# Altium schematic sheet pai.SchDoc(pai)
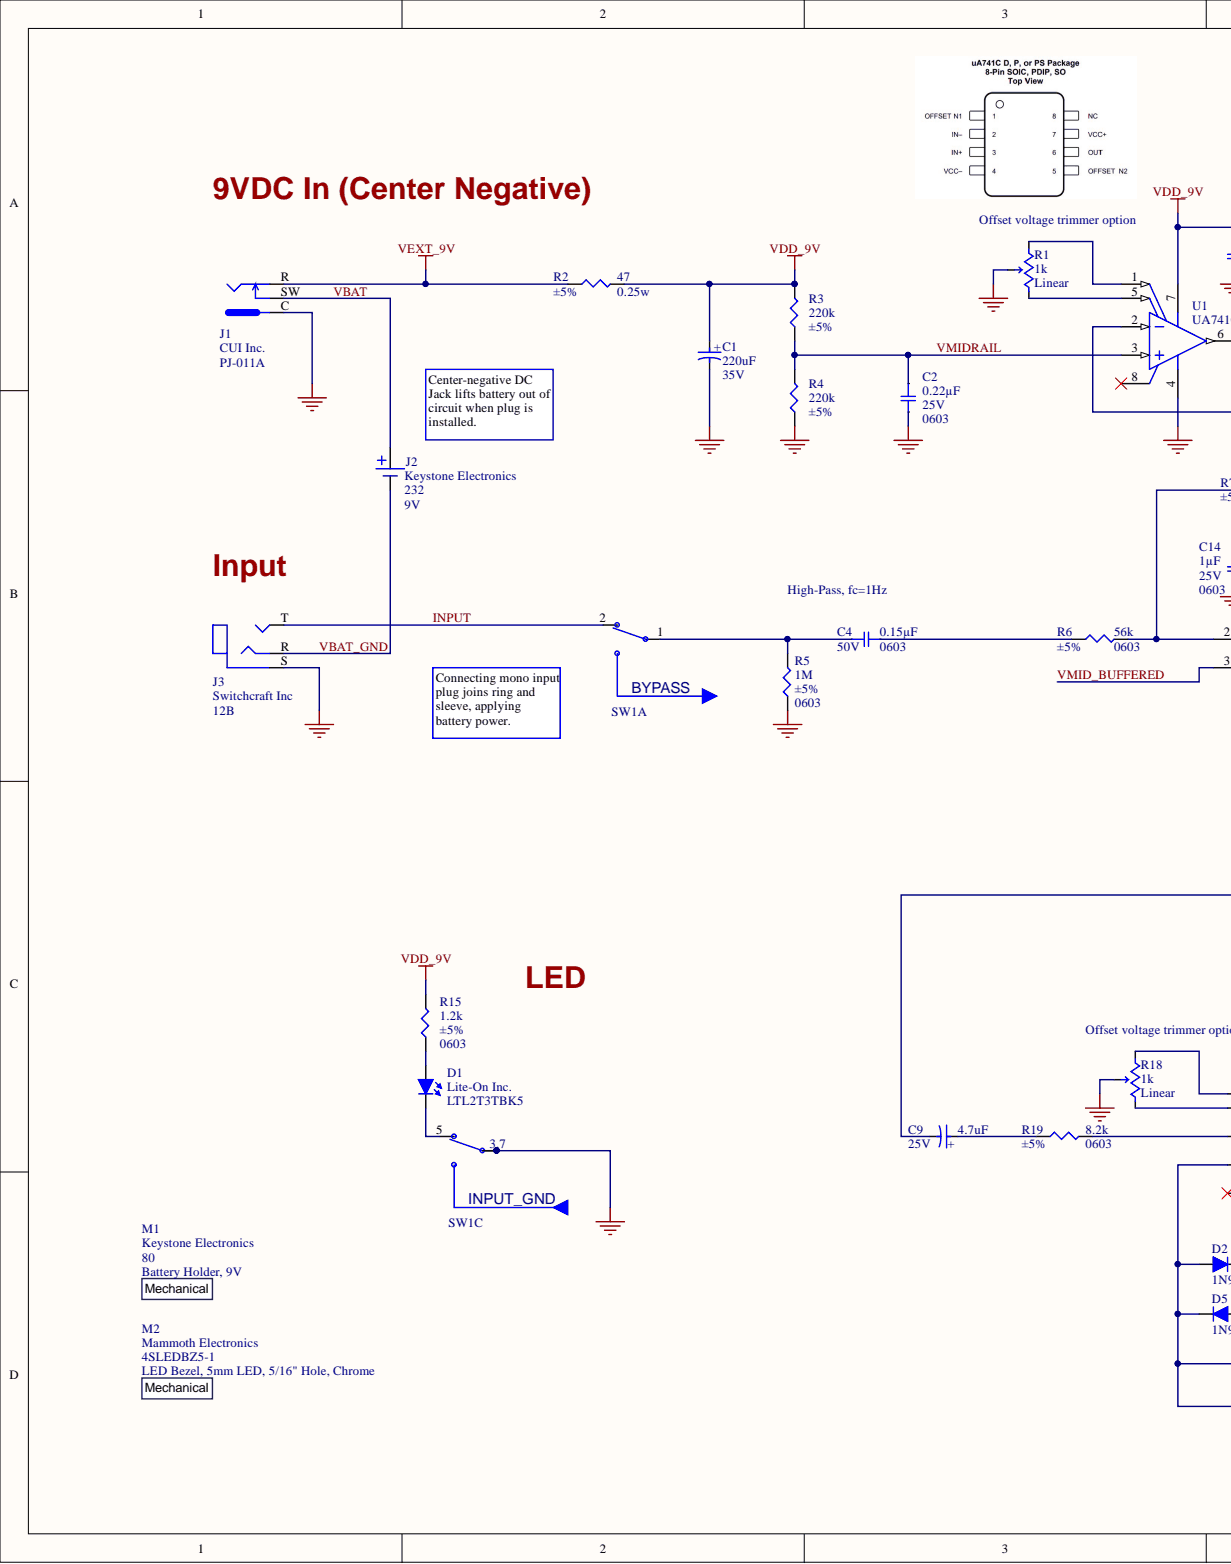
[diagram p0: pai.SchDoc, left]
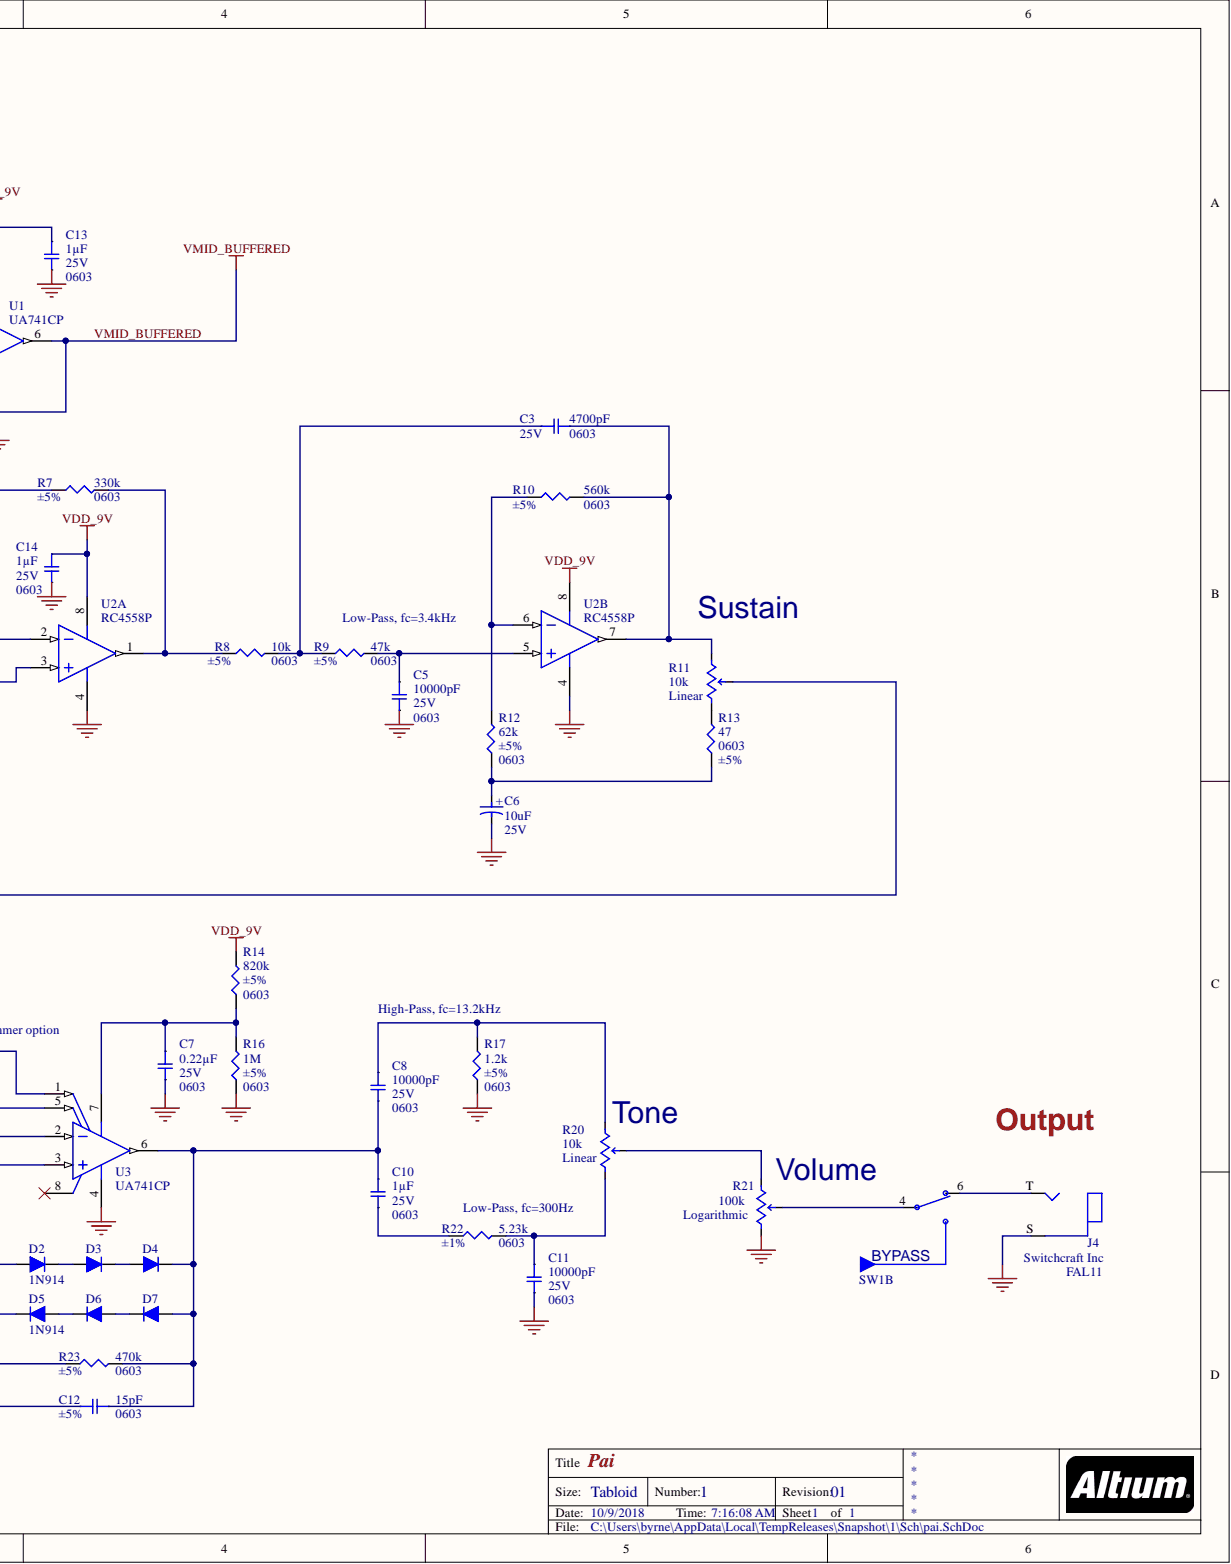
[diagram p1: pai.SchDoc, right]

## Parts
C1  value 220uF  Voltage-Rated: 35V  [CAP_TH_10X14_L5]  (hidden comment: CAP-POL)
C2  0.22µF  Voltage: 25V  Package / Case: 0603  [SMD-0603C]
C3  4700pF  Voltage: 25V  Package / Case: 0603  [SMD-0603C]
C4  0.15µF  Voltage: 50V  Package / Case: 0603  [SMD-0603C]
C5  10000pF  Voltage: 25V  Package / Case: 0603  [SMD-0603C]
C6  value 10uF  Voltage-Rated: 25V  [CAP_TH_5X12_L5]  (hidden comment: CAP-POL)
C7  0.22µF  Voltage: 25V  Package / Case: 0603  [SMD-0603C]
C8  10000pF  Voltage: 25V  Package / Case: 0603  [SMD-0603C]
C9  value 4.7uF  Voltage-Rated: 25V  [CAP_TH_5X12_L2]  (hidden comment: CAP-POL)
C10  1µF  Voltage: 25V  Package / Case: 0603  [SMD-0603C]
C11  10000pF  Voltage: 25V  Package / Case: 0603  [SMD-0603C]
C12  15pF  Tolerance: ±5%  Package / Case: 0603  [SMD-0603C]
C13  1µF  Voltage: 25V  Package / Case: 0603  [SMD-0603C]
C14  1µF  Voltage: 25V  Package / Case: 0603  [SMD-0603C]
D1  Manufacturer 1 PN: LTL2T3TBK5  [LED_TH_5MM_BLUE]  (hidden comment: LED-Blue)
D2  Manufacturer 1 PN: 1N914  [DO35-2_1N914]  (hidden comment: DIO-GEN)
D3  DIO-GEN  [DO35-2_1N914]  (value not drawn)
D4  DIO-GEN  [DO35-2_1N914]  (value not drawn)
D5  Manufacturer 1 PN: 1N914  [DO35-2_1N914]  (hidden comment: DIO-GEN)
D6  DIO-GEN  [DO35-2_1N914]  (value not drawn)
D7  DIO-GEN  [DO35-2_1N914]  (value not drawn)
J1  Manufacturer 1: CUI Inc.  [PWR_JACK_SW_HDR]  (hidden comment: PWR_JACK_SW)
J2  Battery Cell Size: 9V  [PWR_JACK_HDR]  (hidden comment: 9V_BATT)
J3  Manufacturer 1 PN: 12B  Manufacturer 1: Switchcraft Inc  [STEREO_JACK_HDR]  (hidden comment: STEREO_JACK)
J4  Manufacturer 1 PN: FAL11  Manufacturer 1: Switchcraft Inc  [MONO_JACK_HDR]  (hidden comment: MONO_JACK)
M1  Manufacturer 1: Keystone Electronics  Desc: Battery Holder, 9V  (hidden comment: MECH)  (mechanical)
M2  Supplier 1 PN: 4SLEDBZ5-1  Supplier 1: Mammoth Electronics  (hidden comment: MECH)  (mechanical)
R1  value 1k  Taper: Linear  [POT_TT35W]  (hidden comment: POT)
R2  47  Tolerance: ±5%  Power (Watts): 0.25w  [SMD-0603-RES]
R3  220k  Tolerance: ±5%  [SMD-0603-RES]
R4  220k  Tolerance: ±5%  [SMD-0603-RES]
R5  1M  Tolerance: ±5%  Supplier Device Package: 0603  [SMD-0603-RES]
R6  56k  Tolerance: ±5%  Supplier Device Package: 0603  [SMD-0603-RES]
R7  330k  Tolerance: ±5%  Supplier Device Package: 0603  [SMD-0603-RES]
R8  10k  Tolerance: ±5%  Supplier Device Package: 0603  [SMD-0603-RES]
R9  47k  Tolerance: ±5%  Supplier Device Package: 0603  [SMD-0603-RES]
R10  560k  Tolerance: ±5%  Supplier Device Package: 0603  [SMD-0603-RES]
R11  value 10k  Taper: Linear  [POT_16MM_TH_RA]  (hidden comment: POT)
R12  62k  Tolerance: ±5%  Supplier Device Package: 0603  [SMD-0603-RES]
R13  47  Tolerance: ±5%  Supplier Device Package: 0603  [SMD-0603-RES]
R14  820k  Tolerance: ±5%  Supplier Device Package: 0603  [SMD-0603-RES]
R15  1.2k  Tolerance: ±5%  Supplier Device Package: 0603  [SMD-0603-RES]
R16  1M  Tolerance: ±5%  Supplier Device Package: 0603  [SMD-0603-RES]
R17  1.2k  Tolerance: ±5%  Supplier Device Package: 0603  [SMD-0603-RES]
R18  value 1k  Taper: Linear  [POT_TT35W]  (hidden comment: POT)
R19  8.2k  Tolerance: ±5%  Supplier Device Package: 0603  [SMD-0603-RES]
R20  value 10k  Taper: Linear  [POT_16MM_TH_RA]  (hidden comment: POT)
R21  value 100k  Taper: Logarithmic  [POT_16MM_TH_RA]  (hidden comment: POT)
R22  5.23k  Tolerance: ±1%  Supplier Device Package: 0603  [SMD-0603-RES]
R23  470k  Tolerance: ±5%  Supplier Device Package: 0603  [SMD-0603-RES]
SW1  3PDT_SW_SOLDERBOARD  (value not drawn)  (parts A,B,C)
U1  UA741CP  [TI-P8]
U2  RC4558P  [TI-P8]  (parts A,B)
U3  UA741CP  [TI-P8]

## Nets
GND: C1.2 C2.2 C5.2 C6.2 C7.2 C11.2 C13.2 C14.2 J1.C J3.S J4.S R1.2 R4.1 R5.1 R16.1 R17.1 R18.2 R21.3 SW1.3 SW1.7 U1.4 U2.4 U3.4
VDD_9V: C1.1 C13.1 C14.1 R2.2 R3.2 R14.2 R15.2 U1.7 U2.8
VEXT_9V: J1.R R2.1
INPUT: J3.T SW1.2
VBAT: J1.SW J2.1
VBAT_GND: J2.2 J3.R
VMID_BUFFERED: U1.2 U1.6 U2.3
VMIDRAIL: C2.1 R3.1 R4.2 U1.3
NetC3_1: C3.1 R8.2 R9.1
NetC3_2: C3.2 R10.2 R11.1 U2.7
NetC4_1: C4.1 R5.2 SW1.1
NetC4_2: C4.2 R6.1
NetC5_1: C5.1 R9.2 U2.5
NetC6_1: C6.1 R12.1 R13.1
NetC7_1: C7.1 R14.1 R16.2 U3.7
NetC8_1: C8.1 R17.2 R20.1
NetC8_2: C8.2 C10.1 C12.2 D4.K D7.A R23.2 U3.6
NetC9_1: C9.1 R19.1
NetC9_2: C9.2 R11.2
NetC10_2: C10.2 R22.1
NetC11_1: C11.1 R20.3 R22.2
NetC12_1: C12.1 D2.A D5.K R23.1 U3.3
NetD1_A: D1.A R15.1
NetD1_K: D1.K SW1.5
NetD2_K: D2.K D3.A
NetD3_K: D3.K D4.A
NetD5_A: D5.A D6.K
NetD6_A: D6.A D7.K
NetJ4_T: J4.T SW1.6
NetR1_1: R1.1 U1.5
NetR1_3: R1.3 U1.1
NetR6_2: R6.2 R7.1 U2.2
NetR7_2: R7.2 R8.1 U2.1
NetR10_1: R10.1 R12.2 U2.6
NetR11_3: R11.3 R13.2
NetR18_1: R18.1 U3.5
NetR18_3: R18.3 U3.1
NetR19_2: R19.2 U3.2
NetR20_2: R20.2 R21.1
NetR21_2: R21.2 SW1.4

Not connected: U1 8; U3 8

## Library data of the parts
C1 = CAP-TH-0002: CAP ALUM 220UF 20% 35V THRU HOLE; Manufacturer 1 PN: ESH227M035AH9AA; Manufacturer 1: KEMET; Ripple Current @ Low Frequency: 315Ma @ 120Hz; Ripple Current @ High Frequency: 472.5mA @ 10kHz; Polarization: Polar; Lifetime @ Temp: 2000; Database Table Name: Through-Hole$; TPN: CAP-TH-0002; Tolerance: 20%; Supplier 1 PN: 399-15681-ND; Supplier 1 Link: https://www.digikey.com/product-detail/en/kemet/ESH227M035AH9AA/399-15681-ND/7423187; Supplier 1: Digi-Key; Size / Dimension: 0.394" Dia (10.00mm); Package / Case: Radial, Can; Operating Temperature: -40°C ~ 105°C; Mounting Type: Through Hole; Library Name: capacitors.DbLib; Lead Spacing: 0.197" (5.00mm); Height - Seated (Max): 0.551" (14.00mm); ESR: -; Component Kind: Standard
C2 C7 = GRM188R71E224KA88D: CAP CER 0.22UF 25V X7R 0603; Manufacturer: Murata Electronics North America; Unit Price (USD): 0.14; Tolerance: ±10%; Minimum Quantity: 1; Factory Stock: 0; Database Table Name: capacitor_murata_0603$; Value: 0.22µF; Thickness (Max): 0.035" (0.90mm); Temperature Coefficient: X7R; Size / Dimension: 0.063" L x 0.031" W (1.60mm x 0.80mm); Series: GRM; Ratings: -; Quantity Available: 323168; Part Status: Active; Part Number: GRM188R71E224KA88D; Packaging: Cut Tape (CT); Operating Temperature: -55°C ~ 125°C; Mounting Type: Surface Mount, MLCC; Library Name: cap_murata.DbLib; Lead Style: -; Lead Spacing: -; Image: //media.digikey.com/Renders/Murata%20Renders/ZRB-SERIES-0402.jpg; Height - Seated (Max): -; Features: -; Digi-Key Part Number: 490-3290-1-ND; Datasheets: http://www.murata.com/~/media/webrenewal/support/library/catalog/products/capacitor/mlcc/c02e.pdf; Component Kind: Standard; Applications: General Purpose; @ qty: 0
C3 = GCM188R71E472KA37D: CAP CER 4700PF 25V X7R 0603; Manufacturer: Murata Electronics North America; Unit Price (USD): 0.1; Tolerance: ±10%; Minimum Quantity: 1; Factory Stock: 0; Database Table Name: capacitor_murata_0603$; Value: 4700pF; Thickness (Max): 0.035" (0.90mm); Temperature Coefficient: X7R; Size / Dimension: 0.063" L x 0.031" W (1.60mm x 0.80mm); Series: GCM; Ratings: AEC-Q200; Quantity Available: 3228; Part Status: Active; Part Number: GCM188R71E472KA37D; Packaging: Cut Tape (CT); Operating Temperature: -55°C ~ 125°C; Mounting Type: Surface Mount, MLCC; Library Name: cap_murata.DbLib; Lead Style: -; Lead Spacing: -; Image: //media.digikey.com/Renders/Murata%20Renders/0603%28GCM188%29.jpg; Height - Seated (Max): -; Features: -; Digi-Key Part Number: 490-11959-1-ND; Datasheets: http://www.murata.com/~/media/webrenewal/support/library/catalog/products/capacitor/mlcc/c03e.ashx; Component Kind: Standard; Applications: Automotive; @ qty: 0
C4 = GCM188R71H154KA64D: CAP CER 0.15UF 50V X7R 0603; Manufacturer: Murata Electronics North America; Unit Price (USD): 0.19; Tolerance: ±10%; Minimum Quantity: 1; Factory Stock: 0; Database Table Name: capacitor_murata_0603$; Value: 0.15µF; Thickness (Max): 0.035" (0.90mm); Temperature Coefficient: X7R; Size / Dimension: 0.063" L x 0.031" W (1.60mm x 0.80mm); Series: GCM; Ratings: AEC-Q200; Quantity Available: 3950; Part Status: Active; Part Number: GCM188R71H154KA64D; Packaging: Cut Tape (CT); Operating Temperature: -55°C ~ 125°C; Mounting Type: Surface Mount, MLCC; Library Name: cap_murata.DbLib; Lead Style: -; Lead Spacing: -; Image: //media.digikey.com/Renders/Murata%20Renders/0603%28GCM188%29.jpg; Height - Seated (Max): -; Features: -; Digi-Key Part Number: 490-11960-1-ND; Datasheets: http://www.murata.com/~/media/webrenewal/support/library/catalog/products/capacitor/mlcc/c03e.ashx; Component Kind: Standard; Applications: Automotive; @ qty: 0
C5 C8 C11 = GRM188R71E103KA01J: CAP CER 10000PF 25V X7R 0603; Manufacturer: Murata Electronics North America; Unit Price (USD): 0.1; Tolerance: ±10%; Minimum Quantity: 1; Factory Stock: 0; Database Table Name: capacitor_murata_0603$; Value: 10000pF; Thickness (Max): 0.035" (0.90mm); Temperature Coefficient: X7R; Size / Dimension: 0.063" L x 0.031" W (1.60mm x 0.80mm); Series: GRM; Ratings: -; Quantity Available: 8531; Part Status: Active; Part Number: GRM188R71E103KA01J; Packaging: Cut Tape (CT); Operating Temperature: -55°C ~ 125°C; Mounting Type: Surface Mount, MLCC; Library Name: cap_murata.DbLib; Lead Style: -; Lead Spacing: -; Image: //media.digikey.com/Renders/Murata%20Renders/ZRB-SERIES-0402.jpg; Height - Seated (Max): -; Features: -; Digi-Key Part Number: 490-11997-1-ND; Datasheets: http://www.murata.com/~/media/webrenewal/support/library/catalog/products/capacitor/mlcc/c02e.pdf; Component Kind: Standard; Applications: General Purpose; @ qty: 0
C6 = CAP-TH-0001: CAP ALUM 10UF 20% 25V RADIAL; Manufacturer 1 PN: ECA-1EM100B; Manufacturer 1: Panasonic Electronic Components; Ripple Current @ Low Frequency: 50mA @ 120Hz; Ripple Current @ High Frequency: 85mA @ 10kHz; Polarization: Polar; Lifetime @ Temp: 2000; Database Table Name: Through-Hole$; TPN: CAP-TH-0001; Tolerance: 20%; Supplier 1 PN: P19522CT-ND; Supplier 1 Link: https://www.digikey.com/product-detail/en/panasonic-electronic-components/ECA-1EM100B/P19522CT-ND/6109420; Supplier 1: Digi-Key; Size / Dimension: 0.197" Dia (5.00mm)); Package / Case: Radial, Can; Operating Temperature: -40°C ~ 85°C; Mounting Type: Through Hole; Library Name: capacitors.DbLib; Lead Spacing: 0.197" (5.00mm); Height - Seated (Max): 0.472" (12.00mm); ESR: -; Component Kind: Standard
C9 = CAP-TH-0003: CAP ALUM 4.7UF 20% 25V RADIAL; Manufacturer 1 PN: 860020472001; Manufacturer 1: Wurth Electronics Inc.; Ripple Current @ Low Frequency: 26mA @ 120Hz; Ripple Current @ High Frequency: 44.2mA @ 100kHz; Polarization: Polar; Lifetime @ Temp: 2000; Database Table Name: Through-Hole$; TPN: CAP-TH-0003; Tolerance: 20%; Supplier 1 PN: 732-8818-1-ND; Supplier 1 Link: https://www.digikey.com/product-detail/en/wurth-electronics-inc/860020472001/732-8818-1-ND/5728763; Supplier 1: Digi-Key; Size / Dimension: 0.1o97" Dia (5.00mm); Package / Case: Radial, Can; Operating Temperature: -40°C ~ 105°C; Mounting Type: Through Hole; Library Name: capacitors.DbLib; Lead Spacing: 0.079" (2.00mm); Height - Seated (Max): 0.492"(12.5mm); ESR: -; Component Kind: Standard
C10 C13 C14 = GRM188R71E105KA12D: CAP CER 1UF 25V X7R 0603; Manufacturer: Murata Electronics North America; Unit Price (USD): 0.24; Tolerance: ±10%; Minimum Quantity: 1; Factory Stock: 0; Database Table Name: capacitor_murata_0603$; Value: 1µF; Thickness (Max): 0.035" (0.90mm); Temperature Coefficient: X7R; Size / Dimension: 0.063" L x 0.031" W (1.60mm x 0.80mm); Series: GRM; Ratings: -; Quantity Available: 513860; Part Status: Active; Part Number: GRM188R71E105KA12D; Packaging: Cut Tape (CT); Operating Temperature: -55°C ~ 125°C; Mounting Type: Surface Mount, MLCC; Library Name: cap_murata.DbLib; Lead Style: -; Lead Spacing: -; Image: //media.digikey.com/Renders/Murata%20Renders/ZRB-SERIES-0402.jpg; Height - Seated (Max): -; Features: -; Digi-Key Part Number: 490-5307-1-ND; Datasheets: http://www.murata.com/~/media/webrenewal/support/library/catalog/products/capacitor/mlcc/c02e.pdf; Component Kind: Standard; Applications: General Purpose; @ qty: 0
C12 = GCM1885C1H150JA16D: CAP CER 15PF 50V NP0 0603; Manufacturer: Murata Electronics North America; Unit Price (USD): 0.1; Temperature Coefficient: C0G, NP0; Minimum Quantity: 1; Factory Stock: 0; Database Table Name: capacitor_murata_0603$; Voltage: 50V; Value: 15pF; Thickness (Max): 0.035" (0.90mm); Size / Dimension: 0.063" L x 0.031" W (1.60mm x 0.80mm); Series: GCM; Ratings: AEC-Q200; Quantity Available: 99209; Part Status: Active; Part Number: GCM1885C1H150JA16D; Packaging: Cut Tape (CT); Operating Temperature: -55°C ~ 125°C; Mounting Type: Surface Mount, MLCC; Library Name: cap_murata.DbLib; Lead Style: -; Lead Spacing: -; Image: //media.digikey.com/Renders/Murata%20Renders/0603%28GCM188%29.jpg; Height - Seated (Max): -; Features: -; Digi-Key Part Number: 490-4957-1-ND; Datasheets: http://www.murata.com/~/media/webrenewal/support/library/catalog/products/capacitor/mlcc/c03e.ashx; Component Kind: Standard; Applications: Automotive; @ qty: 0
D1 = LED-0003: LED BLUE CLEAR T-1 3/4 T/H; Manufacturer 1: Lite-On Inc.; Wavelength - Peak: 468nm; Wavelength - Dominant: 470nm; Voltage - Forward (Vf) (Typ): 3.5V; Viewing Angle: 30°; Millicandela Rating: 1200mcd; Lens Style: Round with Domed Top; Lens Size: 5mm, T-1 3/4; Lens Color: Colorless; Database Table Name: LED$; Current - Test: 20mA; Configuration: Standard; TPN: LED-0003; Supplier Device Package: T-1 3/4; Supplier 1 PN: 160-1610-ND; Supplier 1 Link: https://www.digikey.com/product-detail/en/lite-on-inc/LTL2T3TBK5/160-1610-ND/573515; Supplier 1: Digi-Key; Size / Dimension: -; Package / Case: Radial; Mounting Type: Through Hole; Library Name: diodes.DbLib; Lens Transparency: Clear; Height (Max): 8.70mm; Features: -; Component Kind: Standard; Color: Blue
D2 D5 = DIO-GEN-0001: DIODE GEN PURP 75V 200MA DO35; Manufacturer 1: Microsemi Corporation; Voltage - Forward (Vf) (Max) @ If: 1.2V @ 50mA; Speed: Small Signal =< 200mA (Io), Any Speed; Database Table Name: general$; Current - Reverse Leakage @ Vr: 500nA @ 75V; Capacitance @ Vr, F: 4pF @ 0V, 1MHz; Voltage - DC Reverse (Vr) (Max): 75V; TPN: DIO-GEN-0001; Supplier Device Packaging: DO-35 (DO-204AH); Supplier 1 PN: 1N914MS-ND; Supplier 1 Link: https://www.digikey.com/product-detail/en/microsemi-corporation/1N914/1N914MS-ND/4377361; Supplier 1: Digi-Key; Package / Case: DO-204AH, DO-35, Axial; Operating Temperature - Junction: -65°C ~ 175°C; Mounting Type: Through Hole; Library Name: diodes.DbLib; Current - Average Rectified (Io): 200mA; Component Kind: Standard
D3 D4 D6 D7 = DIO-GEN-0001: DIODE GEN PURP 75V 200MA DO35; Manufacturer 1 PN: 1N914; Manufacturer 1: Microsemi Corporation; Voltage - Forward (Vf) (Max) @ If: 1.2V @ 50mA; Speed: Small Signal =< 200mA (Io), Any Speed; Database Table Name: general$; Current - Reverse Leakage @ Vr: 500nA @ 75V; Capacitance @ Vr, F: 4pF @ 0V, 1MHz; Voltage - DC Reverse (Vr) (Max): 75V; TPN: DIO-GEN-0001; Supplier Device Packaging: DO-35 (DO-204AH); Supplier 1 PN: 1N914MS-ND; Supplier 1 Link: https://www.digikey.com/product-detail/en/microsemi-corporation/1N914/1N914MS-ND/4377361; Supplier 1: Digi-Key; Package / Case: DO-204AH, DO-35, Axial; Operating Temperature - Junction: -65°C ~ 175°C; Mounting Type: Through Hole; Library Name: diodes.DbLib; Current - Average Rectified (Io): 200mA; Component Kind: Standard
J1 = PWR-CN-0001: CONN PWR JACK 2X5.5MM SOLDER; Manufacturer 1 PN: PJ-011A; Voltage - Rated: 12VDC; Shielding: Unshielded; Number of Positions/Contacts: 2 Conductors, 3 Contacts; Material Flammability Rating: UL94 V-0; Internal Switch(s): Single Switch, Normally Closed; Industry Recognized Mating Diamter: 2.10mm ID (0.083"), 5.50mm OD (0.217"); Includes: 2 pcs - 1 Connector, 1 Nut; Gender: Male; Database Table Name: DC power$; Current Rating: 2A; Body Color: Black; Actual Diameter: 0.079" (2.00mm ID), 0.236" (6.00mm OD); TPN: PWR-CN-0001; Thread Size: -; Termination: Solder Eyelet(s); Supplier 1 PN: CP-011A-ND; Supplier 1 Link: https://www.digikey.com/product-detail/en/cui-inc/PJ-011A/CP-011A-ND/305540; Supplier 1: Digi-Key; Operating Temperature: -; Mounting Type: Panel Mount; Mounting Feature: Bulkhead - Front Side Nut; Mating Length / Depth: -; Library Name: connectors.DbLib; Insulation Color: -; Ingress Protection: -; Housing Material: Polyoxymethylene (POM); Features: Mounting Hardware, Thread Lock; Contact Material - Plating: Nickel; Contact Material: Brass; Copper Alloy; Connector Type: Jack; Component Kind: Standard; Body Material: -
J2 = BATT-0001: BATTERY CONNECT SNAP 9V 4" LEADS; Manufacturer 1 PN: 232; Manufacturer 1: Keystone Electronics; Style: Connector, Snap; Number of Cells: 1; Database Table Name: battery$; Battery Type Function: 9; TPN: BATT-0001; Termination Style: Wire Leads - 4" (101.6mm); Supplier 1 PN: 36-232-ND; Supplier 1 Link: https://www.digikey.com/product-detail/en/keystone-electronics/232/36-232-ND/303804; Supplier 1: Digi-Key; Operating Temperature: -; Mounting Type: Free Hanging; Library Name: connectors.DbLib; Height Above Board: -; Component Kind: Standard; Battery Series: -
J3 = AUD-CN-0001: CONN JACK STEREO 6.35MM PNL MNT; Manufacturer 2 PN: JK100S; Voltage - Rated: 25VDC; Thread Size: 3/8"-32; Singal Lines: Stereo (3 Conductor, TRS); Shielding: Unshielded; Panel Hole Size: 0.375" (9.52mm); Number of Positions/Contacts: 3 Conductors, 3 Contacts; Internal Switch(s): Does Not Contain Switch; Industry Recognized Mating Diameter: 6.35mm (0.250", 1/4") - Headphone; Includes: 3 pcs - 1 Connector, 1 Nut, 1 Washer; Gender: Female; Database Table Name: audio$; Current Rating: 1A; Connector Type: Phone Jack; Body Material: Copper Alloy; Body Color: Silver; Actual Diameter: 0.25" (6.35mm); TPN: AUD-CN-0001; Termination: Solder Eyelet(s); Supplier 2: https://www.mammothelectronics.com/collections/audio-jacks/products/4sjk100s; Supplier 1 PN: SC1094-ND; Supplier 1 Link: https://www.digikey.com/product-detail/en/switchcraft-inc/12B/SC1094-ND/109541; Supplier 1: Digi-Key; Series: LITTLE-JAX; Operating Temperature: -20°C ~ 65°C; Mounting Type: Panel Mount, Through Hole; mating Length/Depth: -; Manufacturer 2: Mammoth Electronics; Library Name: connectors.DbLib; Insulation Color: -; Housing Material: -; Features: Mounting Hardware, Thread Lock; Contact Material - Plating: Nickel; Contact Material: Copper Alloy; Component Kind: Standard; Cable Opening: -
J4 = AUD-CN-0002: CONN JACK MONO 6.35MM PNL MNT; Manufacturer 2 PN: JK100M; Voltage - Rated: 25VDC; Thread Size: 3/8"-32; Shielding: Unshielded; Panel Hole Size: 0.375" (9.52mm); Number of Positions/Contacts: 2 Conductors, 2 Contacts; Internal Switch(s): Does Not Contain Switch; Industry Recognized Mating Diameter: 6.35mm (0.250", 1/4") - Headphone; Includes: 3 pcs - 1 Connector, 1 Nut, 1 Washer; Gender: Female; Database Table Name: audio$; Current Rating: 1A; Connector Type: Phone Jack; Body Material: Copper Alloy; Body Color: Silver; Actual Diameter: 0.25" (6.35mm); TPN: AUD-CN-0002; Termination: Solder Eyelet(s); Supplier 2: https://www.mammothelectronics.com/collections/audio-jacks/products/4sjk100m; Supplier 1 PN: SC1847-ND; Supplier 1 Link: https://www.digikey.com/product-detail/en/switchcraft-inc/FAL11/SC1847-ND/1289066; Supplier 1: Digi-Key; Singal Lines: Mono; Series: LITTLE-JAX; Operating Temperature: -20°C ~ 65°C; Mounting Type: Panel Mount, Through Hole; mating Length/Depth: -; Manufacturer 2: Mammoth Electronics; Library Name: connectors.DbLib; Insulation Color: -; Housing Material: -; Features: Mounting Hardware, Thread Lock; Contact Material - Plating: Nickel; Contact Material: Copper Alloy; Component Kind: Standard; Cable Opening: -
M1 = MECH-0001: BATTERY HOLDER CLIP 9V; Manufacturer 1 PN: 80; Database Table Name: misc$; TPN: MECH-0001; Supplier 1 PN: 36-80-ND; Supplier 1 Link: https://www.digikey.com/product-detail/en/keystone-electronics/80/36-80-ND/2745547; Supplier 1: Digi-Key; Library Name: mechanical.DbLib
M2 = MECH-0002: LED Bezel, 5mm LED, 5/16" Hole, Chrome; Database Table Name: misc$; TPN: MECH-0002; Supplier 1 Link: https://www.mammothelectronics.com/collections/led-bezels/products/4sledbz5-1; Manufacturer 1 PN: 4SLEDBZ5-1; Manufacturer 1: Mammoth Electronics; Desc: LED Bezel, 5mm LED, 5/16" Hole, Chrome; Library Name: mechanical.DbLib
R1 R18 = POT-0021: Potentiometer, 1k, Linear Taper, Trim, Single Gang, Surface Mount; Manufacturer 1 PN: 35WR1KLFTR; Manufacturer 1: TT Elecronics/Bl; Tolerance: 0.25; Temperature Coefficient: ±250ppm/°C; Power (Watts): 0.1; Database Table Name: potentiometers$; TPN: POT-0021; Supplier 1 PN: 987-1812-1-ND; Supplier 1 Link: https://www.digikey.com/product-detail/en/tt-electronics-bi/35WR1KLFTR/987-1812-1-ND/8111752; Supplier 1: Digi-Key; Size / Dimension: Rectangular - 0.144" x 0.118" Face x 0.067" H (3.65mm x 3.00mm x 1.70mm); Mounting Type: Surface Mount; Library Name: electromechanical.DbLib; Component Kind: Standard
R2 = ERJ-PA3J470V: RES SMD 47 OHM 5% 1/4W 0603; Manufacturer: Panasonic Electronic Components; Unit Price (USD): 0.01646; Temperature Coefficient: ±200ppm/°C; Minimum Quantity: 1; Factory Stock: 0; Database Table Name: Panasonic_ERJ_0603; @ qty: 1500; Value: 47; Supplier Device Package: 0603; Size / Dimension: 0.063" L x 0.031" W (1.60mm x 0.80mm); Series: ERJ; Quantity Available: 4817; Part Status: Active; Part Number: ERJ-PA3J470V; Packaging: Cut Tape (CT); Package / Case: 0603 (1608 Metric); Operating Temperature: -55°C ~ 155°C; Number of Terminations: 2; Image: //media.digikey.com/Photos/Panasonic%20Photos/MFG_ERJ-P6W%20Series.jpg; Height - Seated (Max): 0.022" (0.55mm); Features: Automotive AEC-Q200, Pulse Withstanding; Failure Rate: -; Digi-Key Part Number: P47.00BZCT-ND; Datasheets: https://industrial.panasonic.com/cdbs/www-data/pdf/RDA0000/AOA0000C243.pdf; Composition: Thick Film; Component Kind: Standard; Library Name: res_panasonic_erj_xls.DbLib
R3 = ERJ-PA3J224V: RES SMD 220K OHM 5% 1/4W 0603; Manufacturer: Panasonic Electronic Components; Unit Price (USD): 0.01646; Temperature Coefficient: ±200ppm/°C; Power (Watts): 0.25W; Minimum Quantity: 1; Factory Stock: 0; Database Table Name: Panasonic_ERJ_0603; @ qty: 1500; Value: 220k; Supplier Device Package: 0603; Size / Dimension: 0.063" L x 0.031" W (1.60mm x 0.80mm); Series: ERJ; Quantity Available: 19215; Part Status: Active; Part Number: ERJ-PA3J224V; Packaging: Cut Tape (CT); Package / Case: 0603 (1608 Metric); Operating Temperature: -55°C ~ 155°C; Number of Terminations: 2; Image: //media.digikey.com/Photos/Panasonic%20Photos/MFG_ERJ-P6W%20Series.jpg; Height - Seated (Max): 0.022" (0.55mm); Features: Automotive AEC-Q200, Pulse Withstanding; Failure Rate: -; Digi-Key Part Number: P220KBZCT-ND; Datasheets: https://industrial.panasonic.com/cdbs/www-data/pdf/RDA0000/AOA0000C243.pdf; Composition: Thick Film; Component Kind: Standard; Library Name: res_panasonic_erj_xls.DbLib
R4 = ERJ-PA3J224V: RES SMD 220K OHM 5% 1/4W 0603; Manufacturer: Panasonic Electronic Components; Unit Price (USD): 0.01646; Temperature Coefficient: ±200ppm/°C; Power (Watts): 0.25W, 1/4W; Minimum Quantity: 1; Factory Stock: 0; Database Table Name: Panasonic_ERJ_0603; @ qty: 1500; Value: 220k; Supplier Device Package: 0603; Size / Dimension: 0.063" L x 0.031" W (1.60mm x 0.80mm); Series: ERJ; Quantity Available: 19215; Part Status: Active; Part Number: ERJ-PA3J224V; Packaging: Cut Tape (CT); Package / Case: 0603 (1608 Metric); Operating Temperature: -55°C ~ 155°C; Number of Terminations: 2; Image: //media.digikey.com/Photos/Panasonic%20Photos/MFG_ERJ-P6W%20Series.jpg; Height - Seated (Max): 0.022" (0.55mm); Features: Automotive AEC-Q200, Pulse Withstanding; Failure Rate: -; Digi-Key Part Number: P220KBZCT-ND; Datasheets: https://industrial.panasonic.com/cdbs/www-data/pdf/RDA0000/AOA0000C243.pdf; Composition: Thick Film; Component Kind: Standard; Library Name: res_panasonic_erj_xls.DbLib
R5 R16 = ERJ-3GEYJ105V: RES SMD 1M OHM 5% 1/10W 0603; Manufacturer: Panasonic Electronic Components; Unit Price (USD): 0.00321; Temperature Coefficient: ±200ppm/°C; Power (Watts): 0.1W, 1/10W; Minimum Quantity: 1; Factory Stock: 0; Database Table Name: Panasonic_ERJ_0603; @ qty: 1500; Value: 1M; Size / Dimension: 0.063" L x 0.031" W (1.60mm x 0.80mm); Series: ERJ; Quantity Available: 250051; Part Status: Active; Part Number: ERJ-3GEYJ105V; Packaging: Cut Tape (CT); Package / Case: 0603 (1608 Metric); Operating Temperature: -55°C ~ 155°C; Number of Terminations: 2; Image: //media.digikey.com/Photos/Panasonic%20Photos/MFG_ERJ-P6W%20Series.jpg; Height - Seated (Max): 0.022" (0.55mm); Features: Automotive AEC-Q200; Failure Rate: -; Digi-Key Part Number: P1.0MGCT-ND; Datasheets: http://industrial.panasonic.com/www-cgi/jvcr13pz.cgi?E+PZ+3+AOA0001+ERJ3GEYJ105V+7+WW; Composition: Thick Film; Component Kind: Standard; Library Name: res_panasonic_erj_xls.DbLib
R6 = ERJ-3GEYJ563V: RES SMD 56K OHM 5% 1/10W 0603; Manufacturer: Panasonic Electronic Components; Unit Price (USD): 0.00321; Temperature Coefficient: ±200ppm/°C; Power (Watts): 0.1W, 1/10W; Minimum Quantity: 1; Factory Stock: 0; Database Table Name: Panasonic_ERJ_0603; @ qty: 1500; Value: 56k; Size / Dimension: 0.063" L x 0.031" W (1.60mm x 0.80mm); Series: ERJ; Quantity Available: 76734; Part Status: Active; Part Number: ERJ-3GEYJ563V; Packaging: Cut Tape (CT); Package / Case: 0603 (1608 Metric); Operating Temperature: -55°C ~ 155°C; Number of Terminations: 2; Image: //media.digikey.com/Photos/Panasonic%20Photos/MFG_ERJ-P6W%20Series.jpg; Height - Seated (Max): 0.022" (0.55mm); Features: Automotive AEC-Q200; Failure Rate: -; Digi-Key Part Number: P56KGCT-ND; Datasheets: http://industrial.panasonic.com/www-cgi/jvcr13pz.cgi?E+PZ+3+AOA0001+ERJ3GEYJ563V+7+WW; Composition: Thick Film; Component Kind: Standard; Library Name: res_panasonic_erj_xls.DbLib
R7 = ERJ-3GEYJ334V: RES SMD 330K OHM 5% 1/10W 0603; Manufacturer: Panasonic Electronic Components; Unit Price (USD): 0.00321; Temperature Coefficient: ±200ppm/°C; Power (Watts): 0.1W, 1/10W; Minimum Quantity: 1; Factory Stock: 0; Database Table Name: Panasonic_ERJ_0603; @ qty: 1500; Value: 330k; Size / Dimension: 0.063" L x 0.031" W (1.60mm x 0.80mm); Series: ERJ; Quantity Available: 347903; Part Status: Active; Part Number: ERJ-3GEYJ334V; Packaging: Cut Tape (CT); Package / Case: 0603 (1608 Metric); Operating Temperature: -55°C ~ 155°C; Number of Terminations: 2; Image: //media.digikey.com/Photos/Panasonic%20Photos/MFG_ERJ-P6W%20Series.jpg; Height - Seated (Max): 0.022" (0.55mm); Features: Automotive AEC-Q200; Failure Rate: -; Digi-Key Part Number: P330KGCT-ND; Datasheets: http://industrial.panasonic.com/www-cgi/jvcr13pz.cgi?E+PZ+3+AOA0001+ERJ3GEYJ334V+7+WW; Composition: Thick Film; Component Kind: Standard; Library Name: res_panasonic_erj_xls.DbLib
R8 = ERJ-3GEYJ103V: RES SMD 10K OHM 5% 1/10W 0603; Manufacturer: Panasonic Electronic Components; Unit Price (USD): 0.00321; Temperature Coefficient: ±200ppm/°C; Power (Watts): 0.1W, 1/10W; Minimum Quantity: 1; Factory Stock: 0; Database Table Name: Panasonic_ERJ_0603; @ qty: 1500; Value: 10k; Size / Dimension: 0.063" L x 0.031" W (1.60mm x 0.80mm); Series: ERJ; Quantity Available: 7178118; Part Status: Active; Part Number: ERJ-3GEYJ103V; Packaging: Cut Tape (CT); Package / Case: 0603 (1608 Metric); Operating Temperature: -55°C ~ 155°C; Number of Terminations: 2; Image: //media.digikey.com/Photos/Panasonic%20Photos/MFG_ERJ-P6W%20Series.jpg; Height - Seated (Max): 0.022" (0.55mm); Features: Automotive AEC-Q200; Failure Rate: -; Digi-Key Part Number: P10KGCT-ND; Datasheets: http://industrial.panasonic.com/www-cgi/jvcr13pz.cgi?E+PZ+3+AOA0001+ERJ3GEYJ103V+7+WW; Composition: Thick Film; Component Kind: Standard; Library Name: res_panasonic_erj_xls.DbLib
R9 = ERJ-3GEYJ473V: RES SMD 47K OHM 5% 1/10W 0603; Manufacturer: Panasonic Electronic Components; Unit Price (USD): 0.00321; Temperature Coefficient: ±200ppm/°C; Power (Watts): 0.1W, 1/10W; Minimum Quantity: 1; Factory Stock: 0; Database Table Name: Panasonic_ERJ_0603; @ qty: 1500; Value: 47k; Size / Dimension: 0.063" L x 0.031" W (1.60mm x 0.80mm); Series: ERJ; Quantity Available: 661986; Part Status: Active; Part Number: ERJ-3GEYJ473V; Packaging: Cut Tape (CT); Package / Case: 0603; Operating Temperature: -55°C ~ 155°C; Number of Terminations: 2; Image: //media.digikey.com/Photos/Panasonic%20Photos/MFG_ERJ-P6W%20Series.jpg; Height - Seated (Max): 0.022" (0.55mm); Features: Automotive AEC-Q200; Failure Rate: -; Digi-Key Part Number: P47KGCT-ND; Datasheets: http://industrial.panasonic.com/www-cgi/jvcr13pz.cgi?E+PZ+3+AOA0001+ERJ3GEYJ473V+7+WW; Composition: Thick Film; Component Kind: Standard; Library Name: res_panasonic_erj_xls.DbLib
R10 = ERJ-3GEYJ564V: RES SMD 560K OHM 5% 1/10W 0603; Manufacturer: Panasonic Electronic Components; Unit Price (USD): 0.00321; Temperature Coefficient: ±200ppm/°C; Power (Watts): 0.1W, 1/10W; Minimum Quantity: 1; Factory Stock: 0; Database Table Name: Panasonic_ERJ_0603; @ qty: 1500; Value: 560k; Size / Dimension: 0.063" L x 0.031" W (1.60mm x 0.80mm); Series: ERJ; Quantity Available: 49313; Part Status: Active; Part Number: ERJ-3GEYJ564V; Packaging: Cut Tape (CT); Package / Case: 0603 (1608 Metric); Operating Temperature: -55°C ~ 155°C; Number of Terminations: 2; Image: //media.digikey.com/Photos/Panasonic%20Photos/MFG_ERJ-P6W%20Series.jpg; Height - Seated (Max): 0.022" (0.55mm); Features: Automotive AEC-Q200; Failure Rate: -; Digi-Key Part Number: P560KGCT-ND; Datasheets: http://industrial.panasonic.com/www-cgi/jvcr13pz.cgi?E+PZ+3+AOA0001+ERJ3GEYJ564V+7+WW; Composition: Thick Film; Component Kind: Standard; Library Name: res_panasonic_erj_xls.DbLib
R11 R20 = POT-0004: Potentiometer, 10k, Linear Taper, 16mm, Single Gang, TH RA; Manufacturer 1 PN: B10K; Manufacturer 1: Mammoth; Tolerance: 0.05; Power (Watts): 0.25; Database Table Name: potentiometers$; TPN: POT-0004; Supplier 1 PN: B10K; Supplier 1: Mammoth Electronics; Mounting Type: Through-Hole, Right Angle; Library Name: electromechanical.DbLib; Component Kind: Standard
R12 = ERJ-3GEYJ623V: RES SMD 62K OHM 5% 1/10W 0603; Manufacturer: Panasonic Electronic Components; Unit Price (USD): 0.00321; Temperature Coefficient: ±200ppm/°C; Power (Watts): 0.1W, 1/10W; Minimum Quantity: 1; Factory Stock: 0; Database Table Name: Panasonic_ERJ_0603; @ qty: 1500; Value: 62k; Size / Dimension: 0.063" L x 0.031" W (1.60mm x 0.80mm); Series: ERJ; Quantity Available: 62166; Part Status: Active; Part Number: ERJ-3GEYJ623V; Packaging: Cut Tape (CT); Package / Case: 0603 (1608 Metric); Operating Temperature: -55°C ~ 155°C; Number of Terminations: 2; Image: //media.digikey.com/Photos/Panasonic%20Photos/MFG_ERJ-P6W%20Series.jpg; Height - Seated (Max): 0.022" (0.55mm); Features: Automotive AEC-Q200; Failure Rate: -; Digi-Key Part Number: P62KGCT-ND; Datasheets: http://industrial.panasonic.com/www-cgi/jvcr13pz.cgi?E+PZ+3+AOA0001+ERJ3GEYJ623V+7+WW; Composition: Thick Film; Component Kind: Standard; Library Name: res_panasonic_erj_xls.DbLib
R13 = ERJ-3GEYJ470V: RES SMD 47 OHM 5% 1/10W 0603; Manufacturer: Panasonic Electronic Components; Unit Price (USD): 0.00321; Temperature Coefficient: ±200ppm/°C; Power (Watts): 0.1W, 1/10W; Minimum Quantity: 1; Factory Stock: 0; Database Table Name: Panasonic_ERJ_0603; @ qty: 1500; Value: 47; Size / Dimension: 0.063" L x 0.031" W (1.60mm x 0.80mm); Series: ERJ; Quantity Available: 383153; Part Status: Active; Part Number: ERJ-3GEYJ470V; Packaging: Cut Tape (CT); Package / Case: 0603; Operating Temperature: -55°C ~ 155°C; Number of Terminations: 2; Image: //media.digikey.com/Photos/Panasonic%20Photos/MFG_ERJ-P6W%20Series.jpg; Height - Seated (Max): 0.022" (0.55mm); Features: Automotive AEC-Q200; Failure Rate: -; Digi-Key Part Number: P47GCT-ND; Datasheets: http://industrial.panasonic.com/www-cgi/jvcr13pz.cgi?E+PZ+3+AOA0001+ERJ3GEYJ470V+7+WW; Composition: Thick Film; Component Kind: Standard; Library Name: res_panasonic_erj_xls.DbLib
R14 = ERJ-3GEYJ824V: RES SMD 820K OHM 5% 1/10W 0603; Manufacturer: Panasonic Electronic Components; Unit Price (USD): 0.00321; Temperature Coefficient: ±200ppm/°C; Power (Watts): 0.1W, 1/10W; Minimum Quantity: 1; Factory Stock: 0; Database Table Name: Panasonic_ERJ_0603; @ qty: 1500; Value: 820k; Size / Dimension: 0.063" L x 0.031" W (1.60mm x 0.80mm); Series: ERJ; Quantity Available: 37901; Part Status: Active; Part Number: ERJ-3GEYJ824V; Packaging: Cut Tape (CT); Package / Case: 0603 (1608 Metric); Operating Temperature: -55°C ~ 155°C; Number of Terminations: 2; Image: //media.digikey.com/Photos/Panasonic%20Photos/MFG_ERJ-P6W%20Series.jpg; Height - Seated (Max): 0.022" (0.55mm); Features: Automotive AEC-Q200; Failure Rate: -; Digi-Key Part Number: P820KGCT-ND; Datasheets: http://industrial.panasonic.com/www-cgi/jvcr13pz.cgi?E+PZ+3+AOA0001+ERJ3GEYJ824V+7+WW; Composition: Thick Film; Component Kind: Standard; Library Name: res_panasonic_erj_xls.DbLib
R15 R17 = ERJ-3GEYJ122V: RES SMD 1.2K OHM 5% 1/10W 0603; Manufacturer: Panasonic Electronic Components; Unit Price (USD): 0.00321; Temperature Coefficient: ±200ppm/°C; Power (Watts): 0.1W, 1/10W; Minimum Quantity: 1; Factory Stock: 0; Database Table Name: Panasonic_ERJ_0603; @ qty: 1500; Value: 1.2k; Size / Dimension: 0.063" L x 0.031" W (1.60mm x 0.80mm); Series: ERJ; Quantity Available: 117934; Part Status: Active; Part Number: ERJ-3GEYJ122V; Packaging: Cut Tape (CT); Operating Temperature: -55°C ~ 155°C; Number of Terminations: 2; Image: //media.digikey.com/Photos/Panasonic%20Photos/MFG_ERJ-P6W%20Series.jpg; Height - Seated (Max): 0.022" (0.55mm); Features: Automotive AEC-Q200; Failure Rate: -; Digi-Key Part Number: P1.2KGCT-ND; Datasheets: http://industrial.panasonic.com/www-cgi/jvcr13pz.cgi?E+PZ+3+AOA0001+ERJ3GEYJ122V+7+WW; Composition: Thick Film; Component Kind: Standard; Library Name: res_panasonic_erj_xls.DbLib
  R15: Package / Case: 0603
  R17: Package / Case: 0603 (1608 Metric)
R19 = ERJ-3GEYJ822V: RES SMD 8.2K OHM 5% 1/10W 0603; Manufacturer: Panasonic Electronic Components; Unit Price (USD): 0.00321; Temperature Coefficient: ±200ppm/°C; Power (Watts): 0.1W, 1/10W; Minimum Quantity: 1; Factory Stock: 0; Database Table Name: Panasonic_ERJ_0603; @ qty: 1500; Value: 8.2k; Size / Dimension: 0.063" L x 0.031" W (1.60mm x 0.80mm); Series: ERJ; Quantity Available: 123890; Part Status: Active; Part Number: ERJ-3GEYJ822V; Packaging: Cut Tape (CT); Package / Case: 0603 (1608 Metric); Operating Temperature: -55°C ~ 155°C; Number of Terminations: 2; Image: //media.digikey.com/Photos/Panasonic%20Photos/MFG_ERJ-P6W%20Series.jpg; Height - Seated (Max): 0.022" (0.55mm); Features: Automotive AEC-Q200; Failure Rate: -; Digi-Key Part Number: P8.2KGCT-ND; Datasheets: http://industrial.panasonic.com/www-cgi/jvcr13pz.cgi?E+PZ+3+AOA0001+ERJ3GEYJ822V+7+WW; Composition: Thick Film; Component Kind: Standard; Library Name: res_panasonic_erj_xls.DbLib
R21 = POT-0017: Potentiometer, 100k, Log Taper, 16mm, Single Gang, TH RA; Manufacturer 1 PN: B100K; Manufacturer 1: Mammoth; Tolerance: 0.05; Power (Watts): 0.25; Database Table Name: potentiometers$; TPN: POT-0017; Supplier 1 PN: A100K; Supplier 1: Mammoth Electronics; Mounting Type: Through-Hole, Right Angle; Library Name: electromechanical.DbLib; Component Kind: Standard
R22 = ERJ-3EKF5231V: RES SMD 5.23K OHM 1% 1/10W 0603; Manufacturer: Panasonic Electronic Components; Unit Price (USD): 0.00379; Temperature Coefficient: ±100ppm/°C; Power (Watts): 0.1W, 1/10W; Minimum Quantity: 1; Factory Stock: 0; Database Table Name: Panasonic_ERJ_0603; @ qty: 1500; Value: 5.23k; Size / Dimension: 0.063" L x 0.031" W (1.60mm x 0.80mm); Series: ERJ; Quantity Available: 49513; Part Status: Active; Part Number: ERJ-3EKF5231V; Packaging: Cut Tape (CT); Package / Case: 0603 (1608 Metric); Operating Temperature: -55°C ~ 155°C; Number of Terminations: 2; Image: //media.digikey.com/Photos/Panasonic%20Photos/MFG_ERJ-P6W%20Series.jpg; Height - Seated (Max): 0.022" (0.55mm); Features: Automotive AEC-Q200; Failure Rate: -; Digi-Key Part Number: P5.23KHCT-ND; Datasheets: http://industrial.panasonic.com/www-cgi/jvcr13pz.cgi?E+PZ+3+AOA0002+ERJ3EKF5231V+7+WW; Composition: Thick Film; Component Kind: Standard; Library Name: res_panasonic_erj_xls.DbLib
R23 = ERJ-3GEYJ474V: RES SMD 470K OHM 5% 1/10W 0603; Manufacturer: Panasonic Electronic Components; Unit Price (USD): 0.00321; Temperature Coefficient: ±200ppm/°C; Power (Watts): 0.1W, 1/10W; Minimum Quantity: 1; Factory Stock: 0; Database Table Name: Panasonic_ERJ_0603; @ qty: 1500; Value: 470k; Size / Dimension: 0.063" L x 0.031" W (1.60mm x 0.80mm); Series: ERJ; Quantity Available: 18290; Part Status: Active; Part Number: ERJ-3GEYJ474V; Packaging: Cut Tape (CT); Package / Case: 0603 (1608 Metric); Operating Temperature: -55°C ~ 155°C; Number of Terminations: 2; Image: //media.digikey.com/Photos/Panasonic%20Photos/MFG_ERJ-P6W%20Series.jpg; Height - Seated (Max): 0.022" (0.55mm); Features: Automotive AEC-Q200; Failure Rate: -; Digi-Key Part Number: P470KGCT-ND; Datasheets: http://industrial.panasonic.com/www-cgi/jvcr13pz.cgi?E+PZ+3+AOA0001+ERJ3GEYJ474V+7+WW; Composition: Thick Film; Component Kind: Standard; Library Name: res_panasonic_erj_xls.DbLib
U1 U3 = UA741CP: General-Purpose Operational Amplifier, 7 to 36 V, 0 to 70 degC, 8-pin DIP (P8), Pb-Free (RoHS); Manufacturer: Texas Instruments; Vio (25C)(Max)(mV): 6; Total Supply Voltage(Min)(+5V is 5, +/-5V is 10): 7; Total Supply Voltage(Max)(+5V is 5, +/-5V is 10): 36; Sub Family: General Purpose Amplifier, High Supply Voltage Amplifier (>=30V); Slew Rate(Typ)(V/us): 0.5; Number of Channels: 1; Iq per channel(Max)(mA): 2.8; IIB(Max)(pA): 800000; CMRR(Min)(dB): 70; Supplier Part Number 4: 595-UA741CP; Supplier Part Number 3: 60K7043; Supplier Part Number 2: 1564944; Supplier Part Number 1: 296-11107-5-ND; Supplier 4: Mouser; Supplier 3: Newark; Supplier 2: Farnell; Supplier 1: Digi-Key; PartNumber: UA741CP; PackageVersion: 4040082/E, 04/2010; PackageReference: P8; PackageDescription: 8-Pin Plastic In-Line, Body 9.59 x 6.35 mm, Pitch 2.54 mm; Mounting Technology: Through Hole; Library Name: TI Amplifiers and Linear Operational Amplifier General Purpose.IntLib; GBW(Typ)(MHz): 1; Eco-Plan: Pb-Free (RoHS); DatasheetVersion: SLOS094B; ComponentLink3URL: http://www.ti.com/litv/pdf/mpdi001b; ComponentLink3Description: Package Specification; ComponentLink2URL: http://www.ti.com/lit/ds/symlink/ua741.pdf; ComponentLink2Description: Datasheet; ComponentLink1URL: http://www.ti.com/; ComponentLink1Description: Manufacturer URL; Component Kind: Standard; Code_JEDEC: MS-001
U2 = RC4558P: Dual General-Purpose Operational Amplifier, 10 to 30 V, 0 to 70 degC, 8-pin DIP (P8), Pb-Free (RoHS); Manufacturer: Texas Instruments; Vn at 1kHz(Typ)(nV/rtHz): 8; Vio (25C)(Max)(mV): 6; Total Supply Voltage(Min)(+5V is 5, +/-5V is 10): 10; Total Supply Voltage(Max)(+5V is 5, +/-5V is 10): 30; Sub Family: General Purpose Amplifier, High Supply Voltage Amplifier (>=30V), Low Noise Amp (<=10nV/rtHz); Slew Rate(Typ)(V/us): 1.7; Number of Channels: 2; Iq per channel(Max)(mA): 2.8; IIB(Max)(pA): 500000; GBW(Typ)(MHz): 3; CMRR(Min)(dB): 70; Supplier Part Number 4: 595-RC4558P; Supplier Part Number 3: 60K6767; Supplier Part Number 2: 1106010; Supplier Part Number 1: 296-1414-5-ND; Supplier 4: Mouser; Supplier 3: Newark; Supplier 2: Farnell; Supplier 1: Digi-Key; PartNumber: RC4558P; PackageVersion: 4040082/E, 04/2010; PackageReference: P8; PackageDescription: 8-Pin Plastic In-Line, Body 9.59 x 6.35 mm, Pitch 2.54 mm; Mounting Technology: Through Hole; Library Name: TI Amplifiers and Linear Operational Amplifier General Purpose.IntLib; Eco-Plan: Pb-Free (RoHS); DatasheetVersion: SLOS073F; ComponentLink3URL: http://www.ti.com/litv/pdf/mpdi001b; ComponentLink3Description: Package Specification; ComponentLink2URL: http://www.ti.com/lit/ds/symlink/rc4558.pdf; ComponentLink2Description: Datasheet; ComponentLink1URL: http://www.ti.com/; ComponentLink1Description: Manufacturer URL; Component Kind: Standard; Code_JEDEC: MS-001
SW1 = 3PDT_SW_SOLDERBOARD: Library Name: 3PDT_SW_SOLDERBOARD.SchLib; Component Kind: Standard
